# Altium schematic sheet simulation.SchDoc
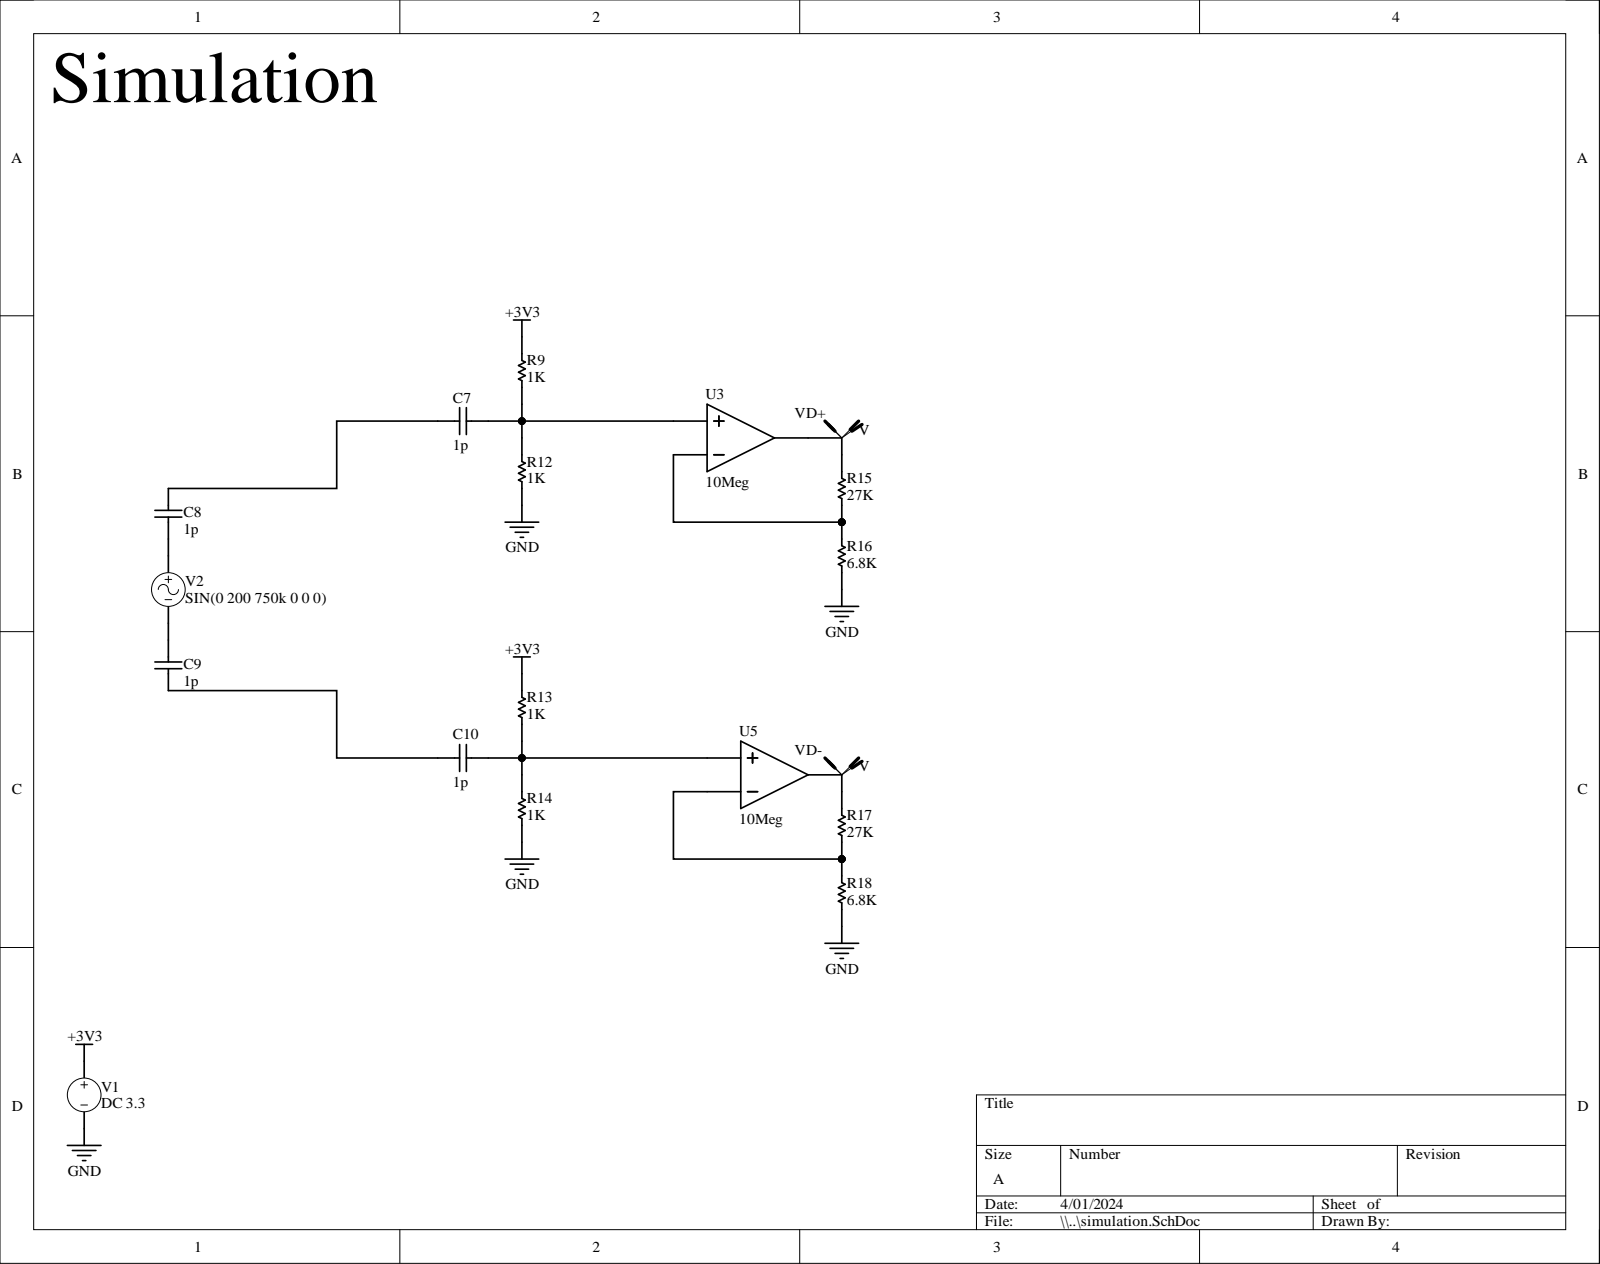
[diagram p0: simulation.SchDoc]

## Parts
C7  value 1p  (hidden comment: Capacitor)
C8  value 1p  (hidden comment: Capacitor)
C9  value 1p  (hidden comment: Capacitor)
C10  value 1p  (hidden comment: Capacitor)
R9  value 1K  (hidden comment: Resistor)
R12  value 1K  (hidden comment: Resistor)
R13  value 1K  (hidden comment: Resistor)
R14  value 1K  (hidden comment: Resistor)
R15  value 27K  (hidden comment: Resistor)
R16  value 6.8K  (hidden comment: Resistor)
R17  value 27K  (hidden comment: Resistor)
R18  value 6.8K  (hidden comment: Resistor)
U3  GAIN: 10Meg  (hidden comment: Ideal Operational Amplifier)
U5  GAIN: 10Meg  (hidden comment: Ideal Operational Amplifier)
V1  DC 3.3  value 3.3
V2  SIN(0 200 750k 0 0 0)

## Nets
+3V3: R9.2 R13.2 V1.1
GND: R12.1 R14.1 R16.1 R18.1 V1.2
NetC7_1: C7.1 C8.2
NetC7_2: C7.2 R9.1 R12.2 U3.2
NetC8_1: C8.1 V2.1
NetC9_1: C9.1 C10.1
NetC9_2: C9.2 V2.2
NetC10_2: C10.2 R13.1 R14.2 U5.2
NetR15_1: R15.1 R16.2 U3.1
NetR15_2: R15.2 U3.3
NetR17_1: R17.1 R18.2 U5.1
NetR17_2: R17.2 U5.3

## Library data of the parts
C7-C10 = Capacitor: SimulationGenericComponent: True; Component Kind: Standard; Description: Capacitor; Library Name: Simulation Generic Components.IntLib; Simulation: C_Capacitor_Default
R9 R12-R18 = Resistor: SimulationGenericComponent: True; TC1: 0; Component Kind: Standard; Description: Resistor; Library Name: Simulation Generic Components.IntLib; Simulation: R_Resistor_Default; TC2: 0
U3 U5 = OpAmp: SimulationGenericComponent: True; Component Kind: Standard; Description: Ideal Operational Amplifier; Library Name: Simulation Generic Components.IntLib; Simulation: IDEALOPAMP_3NODES
V1 = VSRC: Voltage Source; AC Magnitude: 1; AC Phase: 0; Note: PCB Footprint - Not required; Component Kind: Standard; LatestRevisionDate: 17-Jul-2002; LatestRevisionNote: Re-released for DXP Platform.; Library Name: Simulation Generic Components.IntLib; PackageReference: Not Applicable; Published: 8-Jun-2000; Simulation: VSRC; Publisher: Altium Limited
V2 = VSIN: Sinusoidal Voltage Source; AC Magnitude: 1; AC Phase: 0; Amplitude: 200; Note: PCB Footprint - Not required; Component Kind: Standard; Damping Factor: 0; DC Magnitude: 0; Delay: 0; Frequency: 750k; LatestRevisionDate: 15-Nov-2004; LatestRevisionNote: Corrected Netlist.; Library Name: Simulation Generic Components.IntLib; Offset: 0; PackageReference: Not Applicable; Phase: 0; Published: 8-Jun-2000; Simulation: VSIN; Publisher: Altium Limited
